# Altium schematic sheet Current_Sense.SchDoc(Current_Sense)
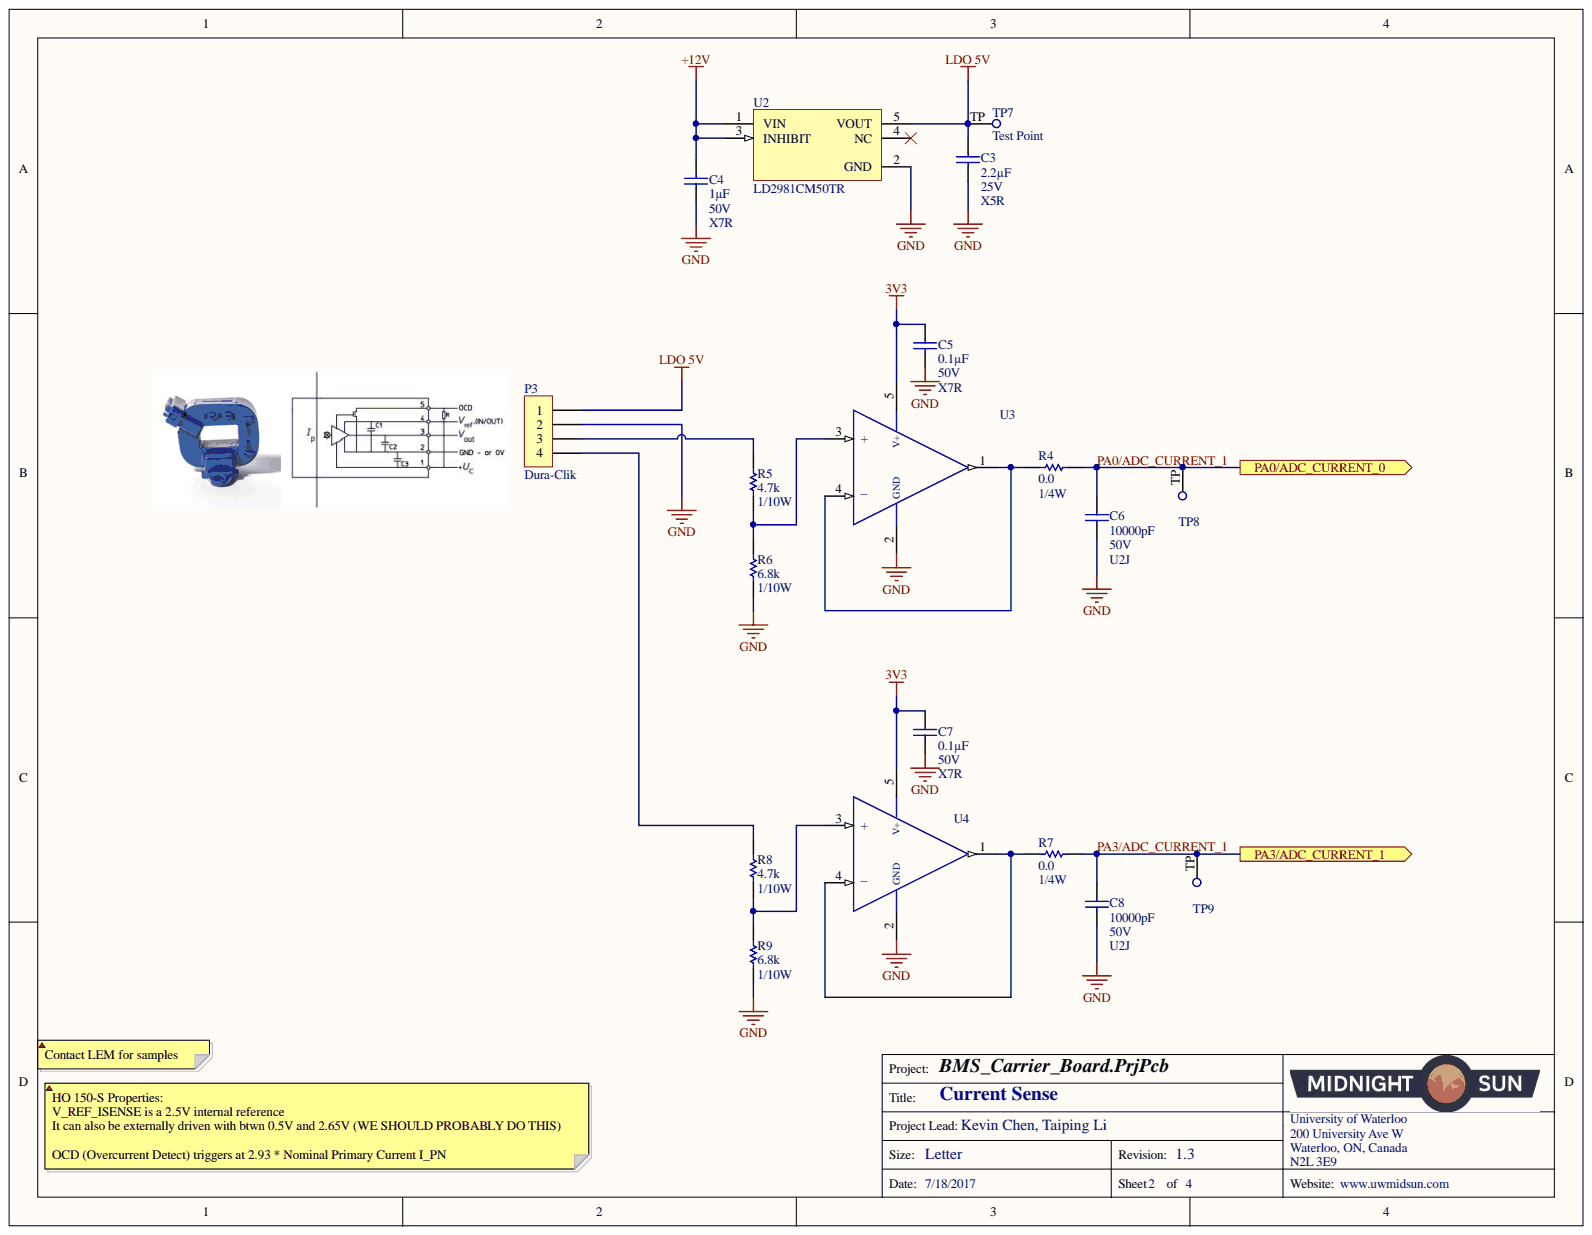
[diagram p0: Current_Sense.SchDoc]

## Parts
C3  Capacitance: 2.2µF  Temperature Coefficient: X5R  Voltage: 25V  [CAP, 0603]  (hidden comment: CAP CER 2.2UF 25V 10% X5R 0603)
C4  Capacitance: 1µF  Temperature Coefficient: X7R  Voltage: 50V  [CAP, 0603]  (hidden comment: CAP CER 1UF 50V 10% X7R 0603)
C5  Capacitance: 0.1µF  Voltage: 50V  [CAP, 0603]  (hidden comment: CAP CER 0.1UF 50V 10% X7R 0603)
C6  Capacitance: 10000pF  Temperature Coefficient: U2J  Voltage: 50V  [CAP, 0603]  (hidden comment: CAP CER 10nF 50V 5% X7R 0603)
C7  Capacitance: 0.1µF  Voltage: 50V  [CAP, 0603]  (hidden comment: CAP CER 0.1UF 50V 10% X7R 0603)
C8  Capacitance: 10000pF  Temperature Coefficient: U2J  Voltage: 50V  [CAP, 0603]  (hidden comment: CAP CER 10nF 50V 5% X7R 0603)
P3  Dura-Clik  [CONN, 4POS DURA-CLIK VERT]
R4  Power (Watts): 1/4W  Resistance: 0.0  [RES, 0603]  (hidden comment: RES 0.0 OHM 1/4W 0603)
R5  Power (Watts): 1/10W  Resistance (Ohms): 4.7k  [RES, 0603]  (hidden comment: RES 4.7K OHM 1% 1/10W 0603)
R6  Power: 1/10W  Resistance: 6.8k  [RES, 0603]  (hidden comment: RES 6.8k OHM 1% 1/10W 0603)
R7  Power (Watts): 1/4W  Resistance: 0.0  [RES, 0603]  (hidden comment: RES 0.0 OHM 1/4W 0603)
R8  Power (Watts): 1/10W  Resistance (Ohms): 4.7k  [RES, 0603]  (hidden comment: RES 4.7K OHM 1% 1/10W 0603)
R9  Power: 1/10W  Resistance: 6.8k  [RES, 0603]  (hidden comment: RES 6.8k OHM 1% 1/10W 0603)
TP7  Test Point  [Test Point]
TP8  Test Point  [Test Point]
TP9  Test Point  [Test Point]
U2  Manufacturer Part Number 1: LD2981CM50TR  [SOT95P280X145-5N]  (hidden comment: IC REG LDO 5V 0.1A SOT23-5)
U3  IC OP AMP GEN PURPOSE RR 10MHZ SOT-23-5  [IC OP AMP GP RR 10MHZ SOT-23-5]  (value not drawn)
U4  IC OP AMP GEN PURPOSE RR 10MHZ SOT-23-5  [IC OP AMP GP RR 10MHZ SOT-23-5]  (value not drawn)

## Ports
PA0/ADC_CURRENT_0, PA3/ADC_CURRENT_1

## Nets
3V3: C5.1 C7.1 U3.5 U4.5
+12V: C4.1 U2.1(VIN) U2.3(INHIBIT)
GND: C3.2 C4.2 C5.2 C6.2 C7.2 C8.2 P3.2 R6.2 R9.2 U2.2(GND) U3.2 U4.2
LDO 5V: C3.1 P3.1 TP7.TP U2.5(VOUT)
PA0/ADC_CURRENT_1: C6.1 R4.2 TP8.TP ; port PA0/ADC_CURRENT_0
PA3/ADC_CURRENT_1: C8.1 R7.2 TP9.TP ; port PA3/ADC_CURRENT_1
NetP3_3: P3.3 R5.1
NetP3_4: P3.4 R8.1
NetR4_1: R4.1 U3.1 U3.4(_)
NetR5_2: R5.2 R6.1 U3.3(+)
NetR7_1: R7.1 U4.1 U4.4
NetR8_2: R8.2 R9.1 U4.3(+)

Not connected: U2 4(NC)

## Library data of the parts
C3 = CAP CER 2.2UF 25V 10% X5R 0603: 2.2µF ±10% 25V Ceramic Capacitor X5R 0603 (1608 Metric); Manufacturer 1: Murata Electronics North America; Manufacturer Part Number 1: GRM188R61E225KA12D; Component Kind: Standard; ComponentLink1Description: http://www.murata.com/~/media/webrenewal/support/library/catalog/products/capacitor/mlcc/c02e.ashx; ComponentLink1URL: http://www.murata.com/~/media/webrenewal/support/library/catalog/products/capacitor/mlcc/c02e.ashx; Height - Seated (Max): -; Lead Spacing: -; Lead Style: -; Mounting Type: Surface Mount, MLCC; Operating Temperature: -55°C ~ 85°C; Package / Case: 0603 (1608 Metric); Packaging: Cut Tape (CT); Part Status: Active; Ratings: -; Size / Dimension: 0.063" L x 0.031" W (1.60mm x 0.80mm); Supplier 1: Digi-Key; Supplier Part Number 1: 490-10731-1-ND; Thickness (Max): 0.035" (0.90mm); Tolerance: ±10%; Library Name: Schematic Diagrams.SchLib
C4 = CAP CER 1UF 50V 10% X7R 0603: 1µF ±10% 50V Ceramic Capacitor X7R 0603 (1608 Metric); Manufacturer 1: Taiyo Yuden; Manufacturer Part Number 1: UMK107AB7105KA-T; Component Kind: Standard; ComponentLink1Description: http://www.yuden.co.jp/productdata/catalog/en/mlcc_all_e.pdf; ComponentLink1URL: http://www.yuden.co.jp/productdata/catalog/en/mlcc_all_e.pdf; Height - Seated (Max): -; Lead Spacing: -; Lead Style: -; Mounting Type: Surface Mount, MLCC; Operating Temperature: -55°C ~ 125°C; Package / Case: 0603 (1608 Metric); Packaging: Cut Tape (CT); Part Status: Active; Ratings: -; Size / Dimension: 0.063" L x 0.031" W (1.60mm x 0.80mm); Supplier 1: Digi-Key; Supplier Part Number 1: 587-3247-1-ND; Thickness (Max): 0.037" (0.95mm); Tolerance: ±10%; Library Name: Schematic Diagrams.SchLib
C5 C7 = CAP CER 0.1UF 50V 10% X7R 0603: 0.10µF ±10% 50V Ceramic Capacitor X7R 0603 (1608 Metric); Manufacturer 1: AVX Corporation; Manufacturer Part Number 1: 06035C104KAT2A; Component Kind: Standard; ComponentLink1Description: http://datasheets.avx.com/X7RDielectric.pdf; ComponentLink1URL: http://datasheets.avx.com/X7RDielectric.pdf; Height - Seated (Max): -; Lead Spacing: -; Lead Style: -; Mounting Type: Surface Mount, MLCC; Operating Temperature: -55°C ~ 125°C; Package / Case: 0603 (1608 Metric); Packaging: Cut Tape (CT); Part Status: Active; Ratings: -; Size / Dimension: 0.063" L x 0.032" W (1.60mm x 0.81mm); Supplier 1: Digi-Key; Supplier Part Number 1: 478-5052-1-ND; Temperature Coefficient: X7R; Thickness (Max): 0.035" (0.90mm); Tolerance: ±10%; Library Name: Schematic Diagrams.SchLib
C6 C8 = CAP CER 10nF 50V 5% X7R 0603: 10000pF ±5% 50V Ceramic Capacitor U2J 0603 (1608 Metric); Manufacturer 1: KEMET; Manufacturer Part Number 1: C0603C103J5JAC7867; Component Kind: Standard; ComponentLink1Description: http://www.kemet.com/docfinder?Partnumber=C0603C103J5JAC7867; ComponentLink1URL: http://www.kemet.com/docfinder?Partnumber=C0603C103J5JAC7867; Failure Rate: -; Height - Seated (Max): -; Lead Spacing: -; Lead Style: -; Mounting Type: Surface Mount, MLCC; Operating Temperature: -55°C ~ 125°C; Package / Case: 0603 (1608 Metric); Packaging: Cut Tape (CT); Part Status: Active; Ratings: -; Size / Dimension: 0.063" L x 0.032" W (1.60mm x 0.80mm); Supplier 1: Digi-Key; Supplier Part Number 1: 399-13384-1-ND; Thickness (Max): 0.034" (0.87mm); Tolerance: ±5%; Library Name: Schematic Diagrams.SchLib
P3 = CONN 4POS DURA-CLIK 0.079": 4 Positions Header Connector 0.079" (2.00mm) Surface Mount Tin; Manufacturer: Molex, LLC; Manufacturer Part Number: 5600200420; Mated Stacking Heights: 9.3mm; Number of Positions: 4; Number of Positions Loaded: All; Shrouding: Shrouded - 4 Wall; Style: Board to Cable/Wire; Applications: Automotive, Industrial; Category: Connectors, Interconnects; Component Kind: Standard; ComponentLink1Description: http://www.molex.com/pdm_docs/sd/5600200420_sd.pdf; ComponentLink1URL: http://www.molex.com/pdm_docs/sd/5600200420_sd.pdf; Connector Type: Header; Contact Finish - Mating: Tin; Contact Finish - Post: Tin; Contact Finish Thickness - Mating: -; Contact Finish Thickness - Post: -; Contact Length - Mating: -; Contact Length - Post: -; Contact Material: Copper Alloy; Contact Shape: Square; Contact Type: Male Pin; Current Rating: -; Fastening Type: Latch Lock; Features: Solder Retention; Ingress Protection: -; Insulation Color: Natural; Insulation Height: 0.315" (8.00mm); Insulation Material: Polyamide (PA), Nylon; Material Flammability Rating: -; Mounting Type: Surface Mount; Number of Rows: 1; Operating Temperature: -; Overall Contact Length: -; Packaging: Cut Tape (CT); Part Status: Active; Pitch - Mating: 0.079" (2.00mm); Pricing 1: 1=2.23, 10=2.022, 25=1.898, 50=1.8156, 100=1.733 (CAD); RoHS: RoHS Compliant; Row Spacing - Mating: -; Stock 1: 9367; Supplier 1: Digi-Key; Supplier Part Number 1: WM10864CT-ND; Termination: Solder; Voltage Rating: -; Library Name: Schematic Diagrams.SchLib
R4 R7 = RES 0.0 OHM 1/4W 0603: RES SMD 0.0 OHM JUMPER 1/4W 0603; Manufacturer 1: Vishay Dale; Manufacturer Part Number 1: CRCW06030000Z0EAHP; Component Kind: Standard; ComponentLink1Description: http://www.vishay.com/docs/20043/crcwhpe3.pdf; ComponentLink1URL: http://www.vishay.com/docs/20043/crcwhpe3.pdf; Composition: Thick Film; Failure Rate: -; Height - Seated (Max): 0.022" (0.55mm); Operating Temperature: -55°C ~ 155°C; Package / Case: 0603 (1608 Metric); Packaging: Cut Tape (CT); Part Status: Active; Size / Dimension: 0.063" L x 0.033" W (1.60mm x 0.85mm); Supplier 1: Digi-Key; Supplier Device Package: 0603; Supplier Part Number 1: 541-0.0SBCT-ND; Library Name: Schematic Diagrams.SchLib
R5 R8 = RES 4.7K OHM 1% 1/10W 0603: 4.7k Ohm ±1% 0.1W, 1/10W Chip Resistor 0603 (1608 Metric) Moisture Resistant Thick Film; Manufacturer 1: Yageo; Manufacturer Part Number 1: RC0603FR-074K7L; Description 1: RES SMD 4.7K OHM 1% 1/10W 0603; Temperature Coefficient: ±100ppm/°C; Category: Resistors; Component Kind: Standard; ComponentLink1Description: http://www.yageo.com/documents/recent/PYu-RC_Group_51_RoHS_L_7.pdf; ComponentLink1URL: http://www.yageo.com/documents/recent/PYu-RC_Group_51_RoHS_L_7.pdf; Composition: Thick Film; Failure Rate: -; Features: Moisture Resistant; Height - Seated (Max): 0.022" (0.55mm); Operating Temperature: -55°C ~ 155°C; Package / Case: 0603 (1608 Metric); Packaging: Cut Tape (CT); Part Status: Active; Pricing 1: 1=0.16, 10=0.022, 100=0.0089, 1000=0.00402, 2500=0.00349 (CAD); RoHS: RoHS Compliant; Size / Dimension: 0.063" L x 0.031" W (1.60mm x 0.80mm); Stock 1: 3487566; Supplier 1: Digi-Key; Supplier Device Package: 0603; Supplier Part Number 1: 311-4.70KHRCT-ND; Tolerance: ±1%; Library Name: Schematic Diagrams.SchLib
R6 R9 = RES 6.8k OHM 1% 1/10W 0603: RES SMD 6.8K OHM 1% 1/10W 0603; Manufacturer 1: Stackpole Electronics Inc.; Manufacturer Part Number 1: RMCF0603FT6K80; Temperature Coefficient: ±100ppm/°C; Component Kind: Standard; ComponentLink1Description: https://www.seielect.com/Catalog/SEI-RMCF_RMCP.pdf; ComponentLink1URL: https://www.seielect.com/Catalog/SEI-RMCF_RMCP.pdf; Composition: Thick Film; Failure Rate: -; Height - Seated (Max): 0.022" (0.55mm); Operating Temperature: -55°C ~ 155°C; Package / Case: 0603 (1608 Metric); Packaging: Cut Tape (CT); Part Status: Active; Size / Dimension: 0.061" L x 0.031" W (1.55mm x 0.80mm); Supplier 1: Digi-Key; Supplier Device Package: 0603; Supplier Part Number 1: RMCF0603FT6K80CT-ND; Tolerance: ±1%; Library Name: Schematic Diagrams.SchLib
U2 = IC REG LDO 5V 0.1A SOT23-5: Linear Voltage Regulator IC Positive Fixed Output 5V 100mA SOT-23-5; Manufacturer 1: STMicroelectronics; Voltage - Dropout (Typical): 0.18V @ 100mA; Voltage - Input: Up to 16V; Component Kind: Standard; ComponentLink1Description: http://www.st.com/content/ccc/resource/technical/document/datasheet/group1/b7/b5/a1/83/9c/bd/4a/90/CD00001635/files/CD00001635.pdf/jcr:content/translations/en.CD00001635.pdf; ComponentLink1URL: http://www.st.com/content/ccc/resource/technical/document/datasheet/group1/b7/b5/a1/83/9c/bd/4a/90/CD00001635/files/CD00001635.pdf/jcr:content/translations/en.CD00001635.pdf; Current - Limit (Min): -; Current - Output: 100mA; Mounting Type: Surface Mount; Operating Temperature: -40°C ~ 125°C; Package / Case: SC-74A, SOT-753; Packaging: Cut Tape (CT); Part Status: Active; Regulator Topology: Positive Fixed; Supplier 1: Digi-Key; Supplier Device Package: SOT-23-5; Supplier Part Number 1: 497-7787-1-ND; Voltage - Output: 5V; Library Name: Schematic Diagrams.SchLib
U3 U4 = IC OP AMP GEN PURPOSE RR 10MHZ SOT-23-5: General Purpose Amplifier 1 Circuit Rail-to-Rail SOT-23-5; Manufacturer 1: Texas Instruments; Manufacturer Part Number 1: TLV316QDBVRQ1; Current - Output / Channel: 50mA; Current - Supply: 400µA; Description 1: IC OP AMP GP RR 10MHZ 5-SOT-23; Number of Circuits: 1; Slew Rate: 6 V/µs; Voltage - Supply, Single/Dual (±): 1.8 V ~ 5.5 V, ±0.9 V ~ 2.75 V; -3db Bandwidth: -; Amplifier Type: General Purpose; Category: Integrated Circuits (ICs); Component Kind: Standard; ComponentLink1Description: http://www.ti.com/lit/ds/symlink/tlv316-q1.pdf; ComponentLink1URL: http://www.ti.com/lit/ds/symlink/tlv316-q1.pdf; Mounting Type: Surface Mount; Operating Temperature: -40°C ~ 125°C; Output Type: Rail-to-Rail; Package / Case: SC-74A, SOT-753; Packaging: Cut Tape (CT); Part Status: Active; Pricing 1: 1=1.33, 10=1.185, 100=0.9234, 500=0.76276, 1000=0.60218 (CAD); RoHS: RoHS Compliant; Stock 1: 1747; Supplier 1: Digi-Key; Supplier Device Package: SOT-23-5; Supplier Part Number 1: 296-45323-1-ND; Library Name: Schematic Diagrams.SchLib
TP7-TP9 = Test Point: Component Kind: Standard; Library Name: Schematic Diagrams.SchLib
